# Altium schematic sheet ALTERA_MAX10_ARDUINO_BOARD_HDMI.SchDoc(ALTERA_MAX10_ARDUINO_BOARD_HDMI)
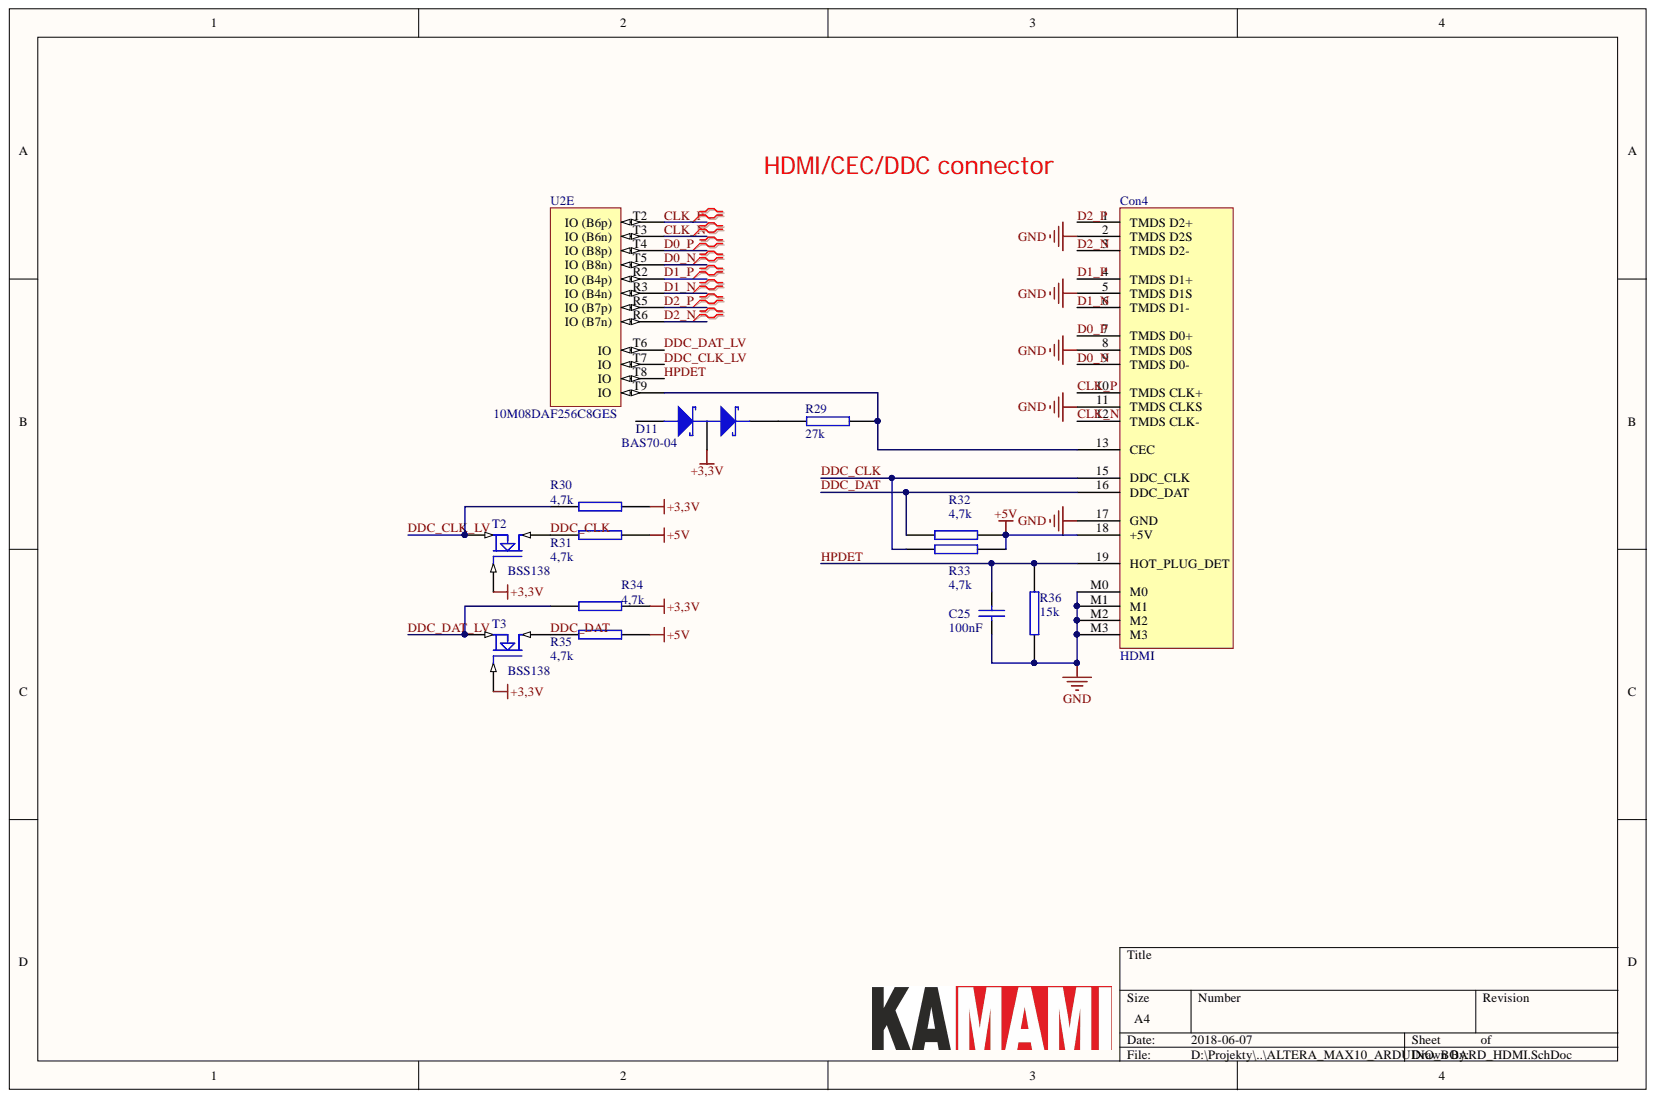
[diagram p0: ALTERA_MAX10_ARDUINO_BOARD_HDMI.SchDoc]

## Parts
C25  100nF  [0603C]
Con4  HDMI  [HDMI_CONN]
D11  BAS70-04  [SOT-23]
R29  27k  [0603R]
R30  4,7k  [0603R]
R31  4,7k  [0603R]
R32  4,7k  [0603R]
R33  4,7k  [0603R]
R34  4,7k  [0603R]
R35  4,7k  [0603R]
R36  15k  [0603R]
T2  BSS138  [SOT-23]
T3  BSS138  [SOT-23]
U2  10M08DAF256C8GES  [BGA256C100P16X16_1700X1700X145]  (part E)

## Nets
+3,3V: D11.3 R30.2 R34.2 T2.1 T3.1
+5V: Con4.18(+5V) R31.2 R32.1 R33.1 R35.2
GND: C25.2 Con4.2(TMDS_D2S) Con4.5(TMDS_D1S) Con4.8(TMDS_D0S) Con4.11(TMDS_CLKS) Con4.17(GND) Con4.M0 Con4.M1 Con4.M2 Con4.M3 R36.1
CLK_N: Con4.12(TMDS_CLK-) U2.T3(IO_(B6n))
CLK_P: Con4.10(TMDS_CLK+) U2.T2(IO_(B6p))
D0_N: Con4.9(TMDS_D0-) U2.T5(IO_(B8n))
D0_P: Con4.7(TMDS_D0+) U2.T4(IO_(B8p))
D1_N: Con4.6(TMDS_D1-) U2.R3(IO_(B4n))
D1_P: Con4.4(TMDS_D1+) U2.R2(IO_(B4p))
D2_N: Con4.3(TMDS_D2-) U2.R6(IO_(B7n))
D2_P: Con4.1(TMDS_D2+) U2.R5(IO_(B7p))
DDC_CLK: Con4.15(DDC_CLK) R31.1 R33.2 T2.3
DDC_CLK_LV: R30.1 T2.2 U2.T7(IO)
DDC_DAT: Con4.16(DDC_DAT) R32.2 R35.1 T3.3
DDC_DAT_LV: R34.1 T3.2 U2.T6(IO)
HPDET: C25.1 Con4.19(HOT_PLUG_DET) R36.2 U2.T8(IO)
NetCon4_13: Con4.13(CEC) R29.1 U2.T9(IO)
NetD11_2: D11.2 R29.2

Not connected: D11 1

## Library data of the parts
C25 = CAP: Capacitor; Library Name: Miscellaneous Devices.SchLib; Component Kind: Standard
D11 = D_DIODE_SCHOTTKY_SERIAL: Library Name: Miscellaneous Devices.SchLib; Component Kind: Standard
R29-R36 = res2: Library Name: Miscellaneous Devices.SchLib; Component Kind: Standard
T2 T3 = MOSFET_N_SOT23: Library Name: Miscellaneous Devices.SchLib; Component Kind: Standard
Con4 = HDMI: Library Name: Miscellaneous Devices.SchLib; Component Kind: Standard
U2 = 10M08DAF256C8GES: Library Name: Altera_MAX10.SchLib; Component Kind: Standard
